# Altium schematic sheet ControlBoard_p1.SchDoc
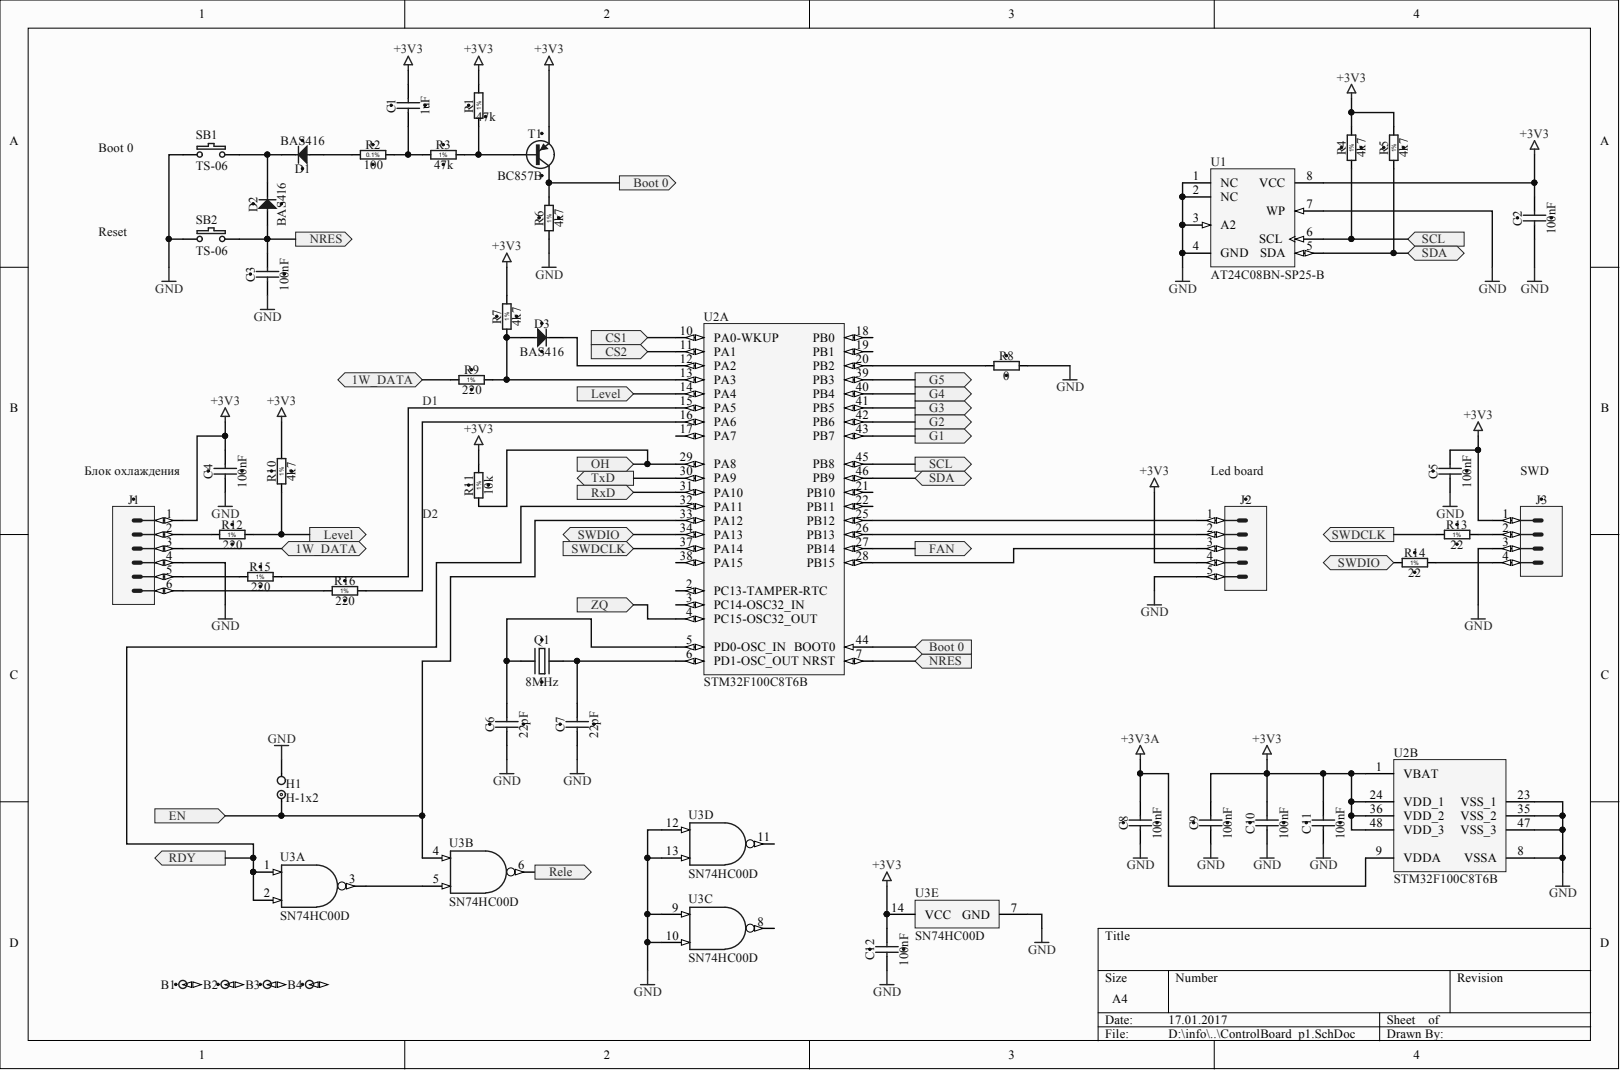
[diagram p0: ControlBoard_p1.SchDoc]

## Parts
B1  Plated Hole  [MTG350_650]  (value not drawn)  (no BOM)
B2  Plated Hole  [MTG350_650]  (value not drawn)  (no BOM)
B3  Plated Hole  [MTG350_650]  (value not drawn)  (no BOM)
B4  Plated Hole  [MTG350_650]  (value not drawn)  (no BOM)
C1  1uF  [CAPC2012X140N]
C2  100nF  [CAPC2012X135N]
C3  100nF  [CAPC2012X135N]
C4  100nF  [CAPC2012X135N]
C5  100nF  [CAPC2012X135N]
C6  22pF  [CAPC2012X135N]
C7  22pF  [CAPC2012X135N]
C8  100nF  [CAPC2012X135N]
C9  100nF  [CAPC2012X135N]
C10  100nF  [CAPC2012X135N]
C11  100nF  [CAPC2012X135N]
C12  100nF  [CAPC2012X135N]
D1  BAS416  [SOD2512X110N]
D2  BAS416  [SOD2512X110N]
D3  BAS416  [SOD2512X110N]
H1  H-1x2  [J1x2]
J1  KK®  [MOLEX_22-27-2061]  (value not drawn)
J2  KK®  [MOLEX_22-27-2051]  (value not drawn)
J3  KK®  [MOLEX_22-27-2041]  (value not drawn)
Q1  8MHz  [XTAL_HC-49/U-S]
R1  47k  [RESC2012X60N]
R2  100  [RESC2012X60N]
R3  47k  [RESC2012X60N]
R4  4k7  [RESC2012X60N]
R5  4k7  [RESC2012X60N]
R6  4k7  [RESC2012X60N]
R7  4k7  [RESC2012X60N]
R8  0  [RESC2012X60N]
R9  220  [RESC2012X60N]
R10  4k7  [RESC2012X60N]
R11  10k  [RESC2012X60N]
R12  220  [RESC2012X60N]
R13  22  [RESC2012X60N]
R14  22  [RESC2012X60N]
R15  220  [RESC2012X60N]
R16  220  [RESC2012X60N]
SB1  TS-06  [TS-06]
SB2  TS-06  [TS-06]
T1  BC857B  [SOT95P230X110-3N]
U1  AT24C08BN-SP25-B  [8S1_N]
U2  STM32F100C8T6B  [STM-LQFP48_N]  (parts A,B)
U3  SN74HC00D  [D0014A_N]  (parts A,B,C,D,E)

## Ports
1W_DATA, BOOT 0, CS1, CS2, EN, FAN, G1, G2, G3, G4, G5, LEVEL, NRES, OH, RDY, RELE, RXD, SCL, SDA, SWDCLK, SWDIO, TXD, ZQ

## Nets
+3V3: C1.2 C2.2 C4.2 C5.2 C9.2 C10.2 C11.2 C12.2 J1.1 J2.4 J3.1 R1.2 R4.2 R5.2 R7.2 R10.2 R11.2 T1.2 U1.8(VCC) U2.1(VBAT) U2.24(VDD_1) U2.36(VDD_2) U2.48(VDD_3) U3.14(VCC)
+3V3A: C8.2 U2.9(VDDA)
GND: C2.1 C3.1 C4.1 C5.1 C6.1 C7.1 C8.1 C9.1 C10.1 C11.1 C12.1 H1.2 J1.4 J2.5 J3.3 R6.1 R8.2 SB1.1 SB2.1 U1.1(NC) U1.2(NC) U1.3(A2) U1.4(GND) U1.7(WP) U2.8(VSSA) U2.23(VSS_1) U2.35(VSS_2) U2.47(VSS_3) U3.7(GND) U3.9 U3.10 U3.12 U3.13
1W_DATA: J1.3 R9.1 ; port 1W_DATA
CS1: U2.10(PA0-WKUP) ; port CS1
CS2: U2.11(PA1) ; port CS2
D1: R15.2 U2.15(PA5)
D2: R16.2 U2.16(PA6)
EN: H1.1 U2.33(PA12) U3.4 ; port EN
FAN: U2.27(PB14) ; port FAN
G1: U2.43(PB7) ; port G1
G2: U2.42(PB6) ; port G2
G3: U2.41(PB5) ; port G3
G4: U2.40(PB4) ; port G4
G5: U2.39(PB3) ; port G5
NRES: C3.2 D2.2 SB2.2 U2.7(NRST) ; port NRES
OH: R11.1 U2.29(PA8) ; port OH
RDY: U2.32(PA11) U3.1 U3.2 ; port RDY
SCL: R4.1 U1.6(SCL) U2.45(PB8) ; port SCL
SDA: R5.1 U1.5(SDA) U2.46(PB9) ; port SDA
SWDCLK: R13.1 U2.37(PA14) ; port SWDCLK
SWDIO: R14.1 U2.34(PA13) ; port SWDIO
ZQ: U2.4(PC15-OSC32_OUT) ; port ZQ
NetC1_1: C1.1 R2.2 R3.1
NetC6_2: C6.2 Q1.1 U2.5(PD0-OSC_IN)
NetC7_2: C7.2 Q1.2 U2.6(PD1-OSC_OUT)
NetD1_1: D1.1 D2.1 SB1.2
NetD1_2: D1.2 R2.1
NetD3_1: D3.1 U2.12(PA2)
NetD3_2: D3.2 R7.1 R9.2 U2.13(PA3)
NetJ1_2: J1.2 R12.1
NetJ1_5: J1.5 R15.1
NetJ1_6: J1.6 R16.1
NetJ2_1: J2.1 U2.25(PB12)
NetJ2_2: J2.2 U2.26(PB13)
NetJ2_3: J2.3 U2.28(PB15)
NetJ3_2: J3.2 R13.2
NetJ3_4: J3.4 R14.2
NetR1_1: R1.1 R3.2 T1.1
NetR6_2: R6.2 T1.3 U2.44(BOOT0) ; port BOOT 0
NetR8_1: R8.1 U2.20(PB2)
NetR10_1: R10.1 R12.2 U2.14(PA4) ; port LEVEL
NetU2_30: U2.30(PA9) ; port TXD
NetU2_31: U2.31(PA10) ; port RXD
NetU3_3: U3.3 U3.5
NetU3_6: U3.6 ; port RELE

Not connected: B1 1; B2 1; B3 1; B4 1; U2 2(PC13-TAMPER-RTC) 3(PC14-OSC32_IN) 17(PA7) 18(PB0) 19(PB1) 21(PB10) 22(PB11) 38(PA15); U3 8 11

## Library data of the parts
B1-B4 = PLATED_HOLE3.5_PAD6.5: Single Terminal Socket; Part Description: Plated Through Hole: Hole Dia.=3.5mm Pad Dia.=6.5mm; Database Table Name: Pads; Mounted: No; ComponentHeight: 0mm; Component Type: Standard (No BOM); CreateDate: 20.11.2008; Family: Plated Hole; LatestRevisionDate: 23.11.2011; Library Name: Eletro-mechanical.DbLib; PackageDescription: Plated Through Hole: Hole Dia.=3.5mm Pad Dia.=6.5mm; Part Number: PLATED_HOLE3.5_PAD6.5; Pin Count: 1; SMD: No; Socket: No; Status: None; Table: Pads; Author: CERN DEM JLC
C1 = CC0805_1UF_35V_20%_X7R: Non-polarised capacitor; Manufacturer: GENERIC; Manufacturer1 Part Number: GMK212B7105MG-T; Part Description: SMD Multilayer Chip Ceramic Capacitor; Database Table Name: Capacitors SMD; TC: X7R; Tolerance: ±20%; Voltage: 35V; Case: 0805; Component Kind: Standard; Component Type: Standard; CreateDate: 22.06.2011; HelpURL: \\cern.ch\dfs\Applications\Altium\Datasheets\CC0805_X7R_TAIYO-YUDEN_HIGH-VALUE.pdf; LatestRevisionDate: 22.06.2011; Library Name: Capacitors.DbLib; Manufacturer1 ComponentHeight: 1.35mm; Manufacturer1 Example: TAIYO YUDEN; Manufacturer Part Number: CC0805_1UF_35V_20%_X7R; Mounted: Yes; Part Number: CC0805_1UF_35V_20%_X7R; Pin Count: 2; SMD: Yes; Socket: No; Status: Not Recommended; Table: Capacitors SMD; Value: 1uF; Author: CERN DEM JLC
C2-C5 C8-C12 = CC0805_100NF_50V_10%_X7R: Non-polarised capacitor; Manufacturer: GENERIC; Manufacturer1 Part Number: B37941K5104K62; Part Description: SMD Multilayer Chip Ceramic Capacitor; Database Table Name: Capacitors SMD; TC: X7R; Tolerance: ±10%; Voltage: 50V; Case: 0805; Component Kind: Standard; Component Type: Standard; CreateDate: 03.12.2007; HelpURL: \\cern.ch\dfs\Applications\Altium\Datasheets\CC0805_X7R_EPCOS.pdf; LatestRevisionDate: 03.12.2007; Library Name: Capacitors.DbLib; Manufacturer1 ComponentHeight: 1.35mm; Manufacturer1 Example: EPCOS; Manufacturer Part Number: CC0805_100NF_50V_10%_X7R; Mounted: Yes; Part Number: CC0805_100NF_50V_10%_X7R; Pin Count: 2; SMD: Yes; Socket: No; Status: Preferred; Table: Capacitors SMD; Value: 100nF; Author: CERN DEM JLC
C6 C7 = CC0805_22PF_50V_5%_NP0: Non-polarised capacitor; Manufacturer: GENERIC; Manufacturer1 Part Number: 223886115229; Part Description: SMD Multilayer Chip Ceramic Capacitor; Database Table Name: Capacitors SMD; TC: NP0; Tolerance: ±5%; Voltage: 50V; Case: 0805; Component Kind: Standard; Component Type: Standard; CreateDate: 03.12.2007; HelpURL: \\cern.ch\dfs\Applications\Altium\Datasheets\CC0805_NP0_PHYCOMP.pdf; LatestRevisionDate: 03.12.2007; Library Name: Capacitors.DbLib; Manufacturer1 ComponentHeight: 1.35mm; Manufacturer1 Example: PHYCOMP; Manufacturer Part Number: CC0805_22PF_50V_5%_NP0; Mounted: Yes; Part Number: CC0805_22PF_50V_5%_NP0; Pin Count: 2; SMD: Yes; Socket: No; Status: Preferred; Table: Capacitors SMD; Value: 22pF; Author: CERN DEM JLC
D1-D3 = BAS416: Diode; Manufacturer: NXP SEMICONDUCTORS; Part Description: Low-Leakage Diode; Database Table Name: Diodes; Power: 200mA; Voltage: 75V; Case: SOD323; ComponentHeight: 1.1mm; Component Kind: Standard; Component Type: Standard; CreateDate: 01.10.2013; Device: BAS416; HelpURL: \\cern.ch\dfs\Applications\Altium\Datasheets\BAS416.pdf; LatestRevisionDate: 01.10.2013; Library Name: ICs And Semiconductors.DbLib; Manufacturer Part Number: BAS416; Mounted: Yes; PackageDescription: Small Outline Diode Flat Lead (SOD-323), 1.70mm W X 1.25mm L X 1.10mm H body,  IPC Medium Density; Part Number: BAS416; Pin Count: 2; SMD: Yes; Socket: No; Table: Diodes; Author: CERN DEM JLC
J1 = MOLEX_22-27-2061: Connector 6 Male; Part Description: 2.54mm (.100") Pitch KK® Wire-to-Board Header, Vertical, with Friction Lock, 6 Circuits, Tin (Sn) Plating; Database Table Name: MOLEX; ComponentHeight: 11.7mm; Component Kind: Standard; Component Type: Standard; CreateDate: 30.11.2011; Family: KK®; HelpURL: \\cern.ch\dfs\Applications\Altium\Datasheets\MOLEX_22-27-2061.pdf; LatestRevisionDate: 30.11.2011; Library Name: Connectors.DbLib; Manufacturer: MOLEX; Manufacturer Part Number: 22-27-2061; Mounted: Yes; Part Number: MOLEX_22-27-2061; Pin Count: 6; SMD: No; Socket: No; Status: None; Table: MOLEX; Author: CERN DEM JMW
J2 = MOLEX_22-27-2051: Connector 5 Male; Part Description: 2.54mm (.100") Pitch KK® Wire-to-Board Header, Vertical, with Friction Lock, 5 Circuits, Tin (Sn) Plating; Database Table Name: MOLEX; ComponentHeight: 11.7mm; Component Kind: Standard; Component Type: Standard; CreateDate: 04.12.2008; Family: KK®; HelpURL: \\cern.ch\dfs\Applications\Altium\Datasheets\MOLEX_22-27-2051.pdf; LatestRevisionDate: 04.12.2008; Library Name: Connectors.DbLib; Manufacturer: MOLEX; Manufacturer Part Number: 22-27-2051; Mounted: Yes; Part Number: MOLEX_22-27-2051; Pin Count: 5; SMD: No; Socket: No; Status: None; Table: MOLEX; Author: CERN DEM JLC
J3 = MOLEX_22-27-2041: Connector 4 Male; Part Description: 2.54mm (.100") Pitch KK® Wire-to-Board Header, Vertical, with Friction Lock, 4 Circuits, Tin (Sn) Plating; Database Table Name: MOLEX; ComponentHeight: 11.7mm; Component Kind: Standard; Component Type: Standard; CreateDate: 04.12.2008; Family: KK®; HelpURL: \\cern.ch\dfs\Applications\Altium\Datasheets\MOLEX_22-27-2041.pdf; LatestRevisionDate: 04.12.2008; Library Name: Connectors.DbLib; Manufacturer: MOLEX; Manufacturer Part Number: 22-27-2041; Mounted: Yes; Part Number: MOLEX_22-27-2041; Pin Count: 4; SMD: No; Socket: No; Status: None; Table: MOLEX; Author: CERN DEM JLC
Q1 = XTAL_5MHZ_CITIZEN_HC49US5.000MABJ-UB: Quartz Crystal; Manufacturer: CITIZEN FINETECH MIYOTA CO; Part Description: Tolerance:± 30ppm, Stability:± 50ppm, -10°C to +60°C, 18pF, Quartz Crystal, HC-49/U-S Serie; Database Table Name: Crystals & Oscillators; ComponentHeight: 3.5mm; Component Kind: Standard; Component Type: Standard; CreateDate: 27.11.2012; HelpURL: \\cern.ch\dfs\Applications\Altium\Datasheets\XTAL_CITIZEN_HC-49-U-S.pdf; LatestRevisionDate: 27.11.2012; Library Name: ICs And Semiconductors.DbLib; Manufacturer Part Number: HC49US5.000MABJ-UB; Mounted: Yes; PackageDescription: HC-49/U-S Leaded Cristal, Body 11.5x4.66mm; Pitch 4.88mm; Part Number: XTAL_5MHZ_CITIZEN_HC49US5.000MABJ-UB; Pin Count: 2; SMD: No; Socket: No; Table: Crystals & Oscillators; Value: 8MHz; Author: CERN DEM JLC
R1 R3 = R0805_47K_1%_0.125W_100PPM: Resistor - 1%; Manufacturer: GENERIC; Manufacturer1 Part Number: NRC10F4702TRF; Part Description: General Purpose Thick Film Chip Resistor; Database Table Name: Resistors SMD; Power: 0.125W; TC: ±100ppm/°C; Case: 0805; Component Kind: Standard; Component Type: Standard; CreateDate: 03.12.2007; HelpURL: \\cern.ch\dfs\Applications\Altium\Datasheets\R0805_NIC_NRC.pdf; LatestRevisionDate: 13.03.2008; Library Name: Resistors.DbLib; Manufacturer1 ComponentHeight: 0.6mm; Manufacturer1 Example: NIC COMPONENT; Manufacturer Part Number: R0805_47K_1%_0.125W_100PPM; Mounted: Yes; Part Number: R0805_47K_1%_0.125W_100PPM; Pin Count: 2; SMD: Yes; Socket: No; Status: Preferred; Table: Resistors SMD; Tolerance: ±1%; Value: 47k; Author: CERN DEM JLC
R2 = R0805_100R_0.1%_0.1W_10PPM: Resistor - 0.1%; Manufacturer: GENERIC; Manufacturer1 Part Number: RN73C2A100RBTG; Part Description: Thin Film Chip Resistor; Database Table Name: Resistors SMD; Power: 0.1W; TC: ±10ppm; Case: 0805; Component Kind: Standard; Component Type: Standard; CreateDate: 03.12.2007; HelpURL: \\cern.ch\dfs\Applications\Altium\Datasheets\R0805_Tyco_RN73.pdf; LatestRevisionDate: 13.03.2008; Library Name: Resistors.DbLib; Manufacturer1 ComponentHeight: 0.6mm; Manufacturer1 Example: TYCO HOLSWORTHY; Manufacturer Part Number: R0805_100R_0.1%_0.1W_10PPM; Mounted: Yes; Part Number: R0805_100R_0.1%_0.1W_10PPM; Pin Count: 2; SMD: Yes; Socket: No; Status: Not recommended; Table: Resistors SMD; Tolerance: ±0.1%; Value: 100; Author: CERN DEM JLC
R4-R7 R10 = R0805_4K7_1%_0.125W_100PPM: Resistor - 1%; Manufacturer: GENERIC; Manufacturer1 Part Number: NRC10F4701TRF; Part Description: General Purpose Thick Film Chip Resistor; Database Table Name: Resistors SMD; Power: 0.125W; TC: ±100ppm/°C; Case: 0805; Component Kind: Standard; Component Type: Standard; CreateDate: 03.12.2007; HelpURL: \\cern.ch\dfs\Applications\Altium\Datasheets\R0805_NIC_NRC.pdf; LatestRevisionDate: 13.03.2008; Library Name: Resistors.DbLib; Manufacturer1 ComponentHeight: 0.6mm; Manufacturer1 Example: NIC COMPONENT; Manufacturer Part Number: R0805_4K7_1%_0.125W_100PPM; Mounted: Yes; Part Number: R0805_4K7_1%_0.125W_100PPM; Pin Count: 2; SMD: Yes; Socket: No; Status: Preferred; Table: Resistors SMD; Tolerance: ±1%; Value: 4k7; Author: CERN DEM JLC
R8 = R0805_0R_JUMPER: Resistor; Manufacturer: GENERIC; Manufacturer1 Part Number: NRC10Z0; Part Description: 2A (0.05R Max DC Resistance) Zero Ohm Jumper; Database Table Name: Resistors SMD; Case: 0805; Component Kind: Standard; Component Type: Standard; CreateDate: 03.12.2007; HelpURL: \\cern.ch\dfs\Applications\Altium\Datasheets\R0805_NIC_NRC.pdf; LatestRevisionDate: 13.03.2008; Library Name: Resistors.DbLib; Manufacturer1 ComponentHeight: 0.6mm; Manufacturer1 Example: NIC COMPONENT; Manufacturer Part Number: R0805_0R_JUMPER; Mounted: Yes; Part Number: R0805_0R_JUMPER; Pin Count: 2; SMD: Yes; Socket: No; Status: Preferred; Table: Resistors SMD; Value: 0; Author: CERN DEM JLC
R9 R12 R15 R16 = R0805_220R_1%_0.125W_100PPM: Resistor - 1%; Manufacturer: GENERIC; Manufacturer1 Part Number: NRC10F2200TRF; Part Description: General Purpose Thick Film Chip Resistor; Database Table Name: Resistors SMD; Power: 0.125W; TC: ±100ppm/°C; Case: 0805; Component Kind: Standard; Component Type: Standard; CreateDate: 03.12.2007; HelpURL: \\cern.ch\dfs\Applications\Altium\Datasheets\R0805_NIC_NRC.pdf; LatestRevisionDate: 13.03.2008; Library Name: Resistors.DbLib; Manufacturer1 ComponentHeight: 0.6mm; Manufacturer1 Example: NIC COMPONENT; Manufacturer Part Number: R0805_220R_1%_0.125W_100PPM; Mounted: Yes; Part Number: R0805_220R_1%_0.125W_100PPM; Pin Count: 2; SMD: Yes; Socket: No; Status: Preferred; Table: Resistors SMD; Tolerance: ±1%; Value: 220; Author: CERN DEM JLC
R11 = R0805_10K_1%_0.125W_100PPM: Resistor - 1%; Manufacturer: GENERIC; Manufacturer1 Part Number: NRC10F1002TRF; Part Description: General Purpose Thick Film Chip Resistor; Database Table Name: Resistors SMD; Power: 0.125W; TC: ±100ppm/°C; Case: 0805; Component Kind: Standard; Component Type: Standard; CreateDate: 03.12.2007; HelpURL: \\cern.ch\dfs\Applications\Altium\Datasheets\R0805_NIC_NRC.pdf; LatestRevisionDate: 13.03.2008; Library Name: Resistors.DbLib; Manufacturer1 ComponentHeight: 0.6mm; Manufacturer1 Example: NIC COMPONENT; Manufacturer Part Number: R0805_10K_1%_0.125W_100PPM; Mounted: Yes; Part Number: R0805_10K_1%_0.125W_100PPM; Pin Count: 2; SMD: Yes; Socket: No; Status: Preferred; Table: Resistors SMD; Tolerance: ±1%; Value: 10k; Author: CERN DEM JLC
R13 R14 = R0805_22R_1%_0.125W_100PPM: Resistor - 1%; Manufacturer: GENERIC; Manufacturer1 Part Number: NRC10F22R0TRF; Part Description: General Purpose Thick Film Chip Resistor; Database Table Name: Resistors SMD; Power: 0.125W; TC: ±100ppm/°C; Case: 0805; Component Kind: Standard; Component Type: Standard; CreateDate: 03.12.2007; HelpURL: \\cern.ch\dfs\Applications\Altium\Datasheets\R0805_NIC_NRC.pdf; LatestRevisionDate: 13.03.2008; Library Name: Resistors.DbLib; Manufacturer1 ComponentHeight: 0.6mm; Manufacturer1 Example: NIC COMPONENT; Manufacturer Part Number: R0805_22R_1%_0.125W_100PPM; Mounted: Yes; Part Number: R0805_22R_1%_0.125W_100PPM; Pin Count: 2; SMD: Yes; Socket: No; Status: Preferred; Table: Resistors SMD; Tolerance: ±1%; Value: 22; Author: CERN DEM JLC
SB1 SB2 = Buton TS-06: Component Kind: Standard; Library Name: Devise.IntLib; Price: 0.15
T1 = BC857B: PNP Transistor; Manufacturer: NXP SEMICONDUCTORS; Part Description: 45V 100mA PNP general-purpose transistors; Database Table Name: Transistors; Case: SOT23-3; ComponentHeight: 1.1mm; Component Kind: Standard; Component Type: Standard; CreateDate: 14.06.2011; Device: BC857B; HelpURL: \\cern.ch\dfs\Applications\Altium\Datasheets\BC857B.pdf; LatestRevisionDate: 14.06.2011; Library Name: ICs And Semiconductors.DbLib; Manufacturer Part Number: BC857B; Mounted: Yes; PackageDescription: SOT23, 3 pin, Pitch 0.95mm - 1.3mm W X 2.9mm L X 1.1mm H, IPC Medium Density; Part Number: BC857B; Pin Count: 3; SMD: Yes; Socket: No; Table: Transistors; Author: CERN DEM JLC
U1 = AT24C08BN-SP25-B: 8Kbit (1024 x 8), 2-wire Bus Automotive Serial EEPROM with Write Protect, 2.5V, 8-Pin SOIC, Bulk; Code_IPC: SOIC127P600-8; Code_JEDEC: MS-012-AA; Component Kind: Standard; ComponentLink1Description: Manufacturer URL; ComponentLink1URL: http://www.atmel.com/; ComponentLink2Description: Datasheet; ComponentLink2URL: http://www.atmel.com/dyn/resources/prod_documents/doc8517.pdf; DatasheetVersion: 8517C,  Jan-2009; Library Name: Atmel Serial EEPROM.IntLib; PackageDescription: 8-Lead (0.150" Wide Body), Plastic Gull Wing Small Outline (JEDEC SOIC); PackageReference: 8S1; PackageVersion: revC, Mar-2005
U2 = STM32F100C8T6B: ARM Cortex-M3 32-bit MCU, 64 KB Flash, 8 KB Internal RAM, 37 I/Os, 48-pin LQFP, -40 to 85 degC, Tray; A/D Converter: 1x12-bit (10 Channel); D/A Converter: 2x12-bit (2 Channel); FLASH Size (Prog) (kB): 64; I/Os (High Current): 37; Internal RAM Size (kB): 8; Operating Frequency(F) (Processor speed) (MHz): 24; Supply Voltage(Vcc) min (V): 2; Component Kind: Standard; ComponentLink1Description: Manufacturer URL; ComponentLink1URL: http://www.st.com/web/en/home.html; ComponentLink2Description: Datasheet; ComponentLink2URL: http://www.st.com/st-web-ui/static/active/en/resource/technical/document/datasheet/CD00251732.pdf; ComponentLink3Description: Package Specification; ComponentLink3URL: http://www.st.com/st-web-ui/static/active/en/resource/technical/document/datasheet/DM00058181.pdf; Core: ARM Cortex-M3; DatasheetVersion: Rev. 7; Library Name: STMicroelectronics STM32 F1.IntLib; Mounting Technology: Surface Mount; PackageDescription: 48-Pin Low Profile Quad Flat Package, 7 x 7 mm Body, 0.5 mm Pitch; PackageReference: LQFP48; PackageVersion: Rev. 6, 06/2013; Packing: Tray; PartNumber: STM32F100C8T6B; Supplier 1: Digi-Key; Supplier 2: Farnell; Supplier 3: Newark; Supplier 4: Mouser; Supplier Part Number 1: 497-10499-ND; Supplier Part Number 2: 1838511; Supplier Part Number 3: 76R0988; Supplier Part Number 4: 511-STM32F100C8T6B
U3 = SN74HC00D: Quad 2-Input Positive-NAND Gates, D0014A, TUBE; Manufacturer: Texas Instruments; Bits(#): 4; F @ Nom Voltage(Max)(Mhz): 70; ICC @ Nom Voltage(Max)(mA): 0.02; Input Type: CMOS; Output Drive (IOL/IOH)(Max)(mA): 5.2/-5.2; Rating: Catalog; Schmitt Trigger: Yes; Technology Family: HC; tpd @ Nom Voltage(Max)(ns): 23; VCC(Max)(V): 6; VCC(Min)(V): 2; Voltage(Nom)(V): 5; Code_JEDEC: MS-012; Component Kind: Standard; ComponentLink1Description: Manufacturer URL; ComponentLink1URL: http://www.ti.com/; ComponentLink2Description: Datasheet; ComponentLink2URL: http://www.ti.com/lit/ds/symlink/sn74hc00.pdf; ComponentLink3Description: Package Specification; ComponentLink3URL: http://www.ti.com/lit/ml/mpds177g/mpds177g.pdf; DatasheetVersion: SCLS181E; Generic Part Number: SN74HC00; Library Name: TI_Logic_Gate.IntLib; Operating Temperature Range(C): -40 to 85; Output Type: CMOS; PackageReference: D0014A; PartNumber: SN74HC00D; Supplier 1: Mouser; Supplier 2: Digi-Key; Supplier Part Number 1: 595-SN74HC00D; Supplier Part Number 2: 296-1187-5-ND
H1 = H-1x2: Component Kind: Standard; Library Name: Connektor.IntLib; Price: 0.02
